# Altium schematic sheet INTERFACE.SchDoc
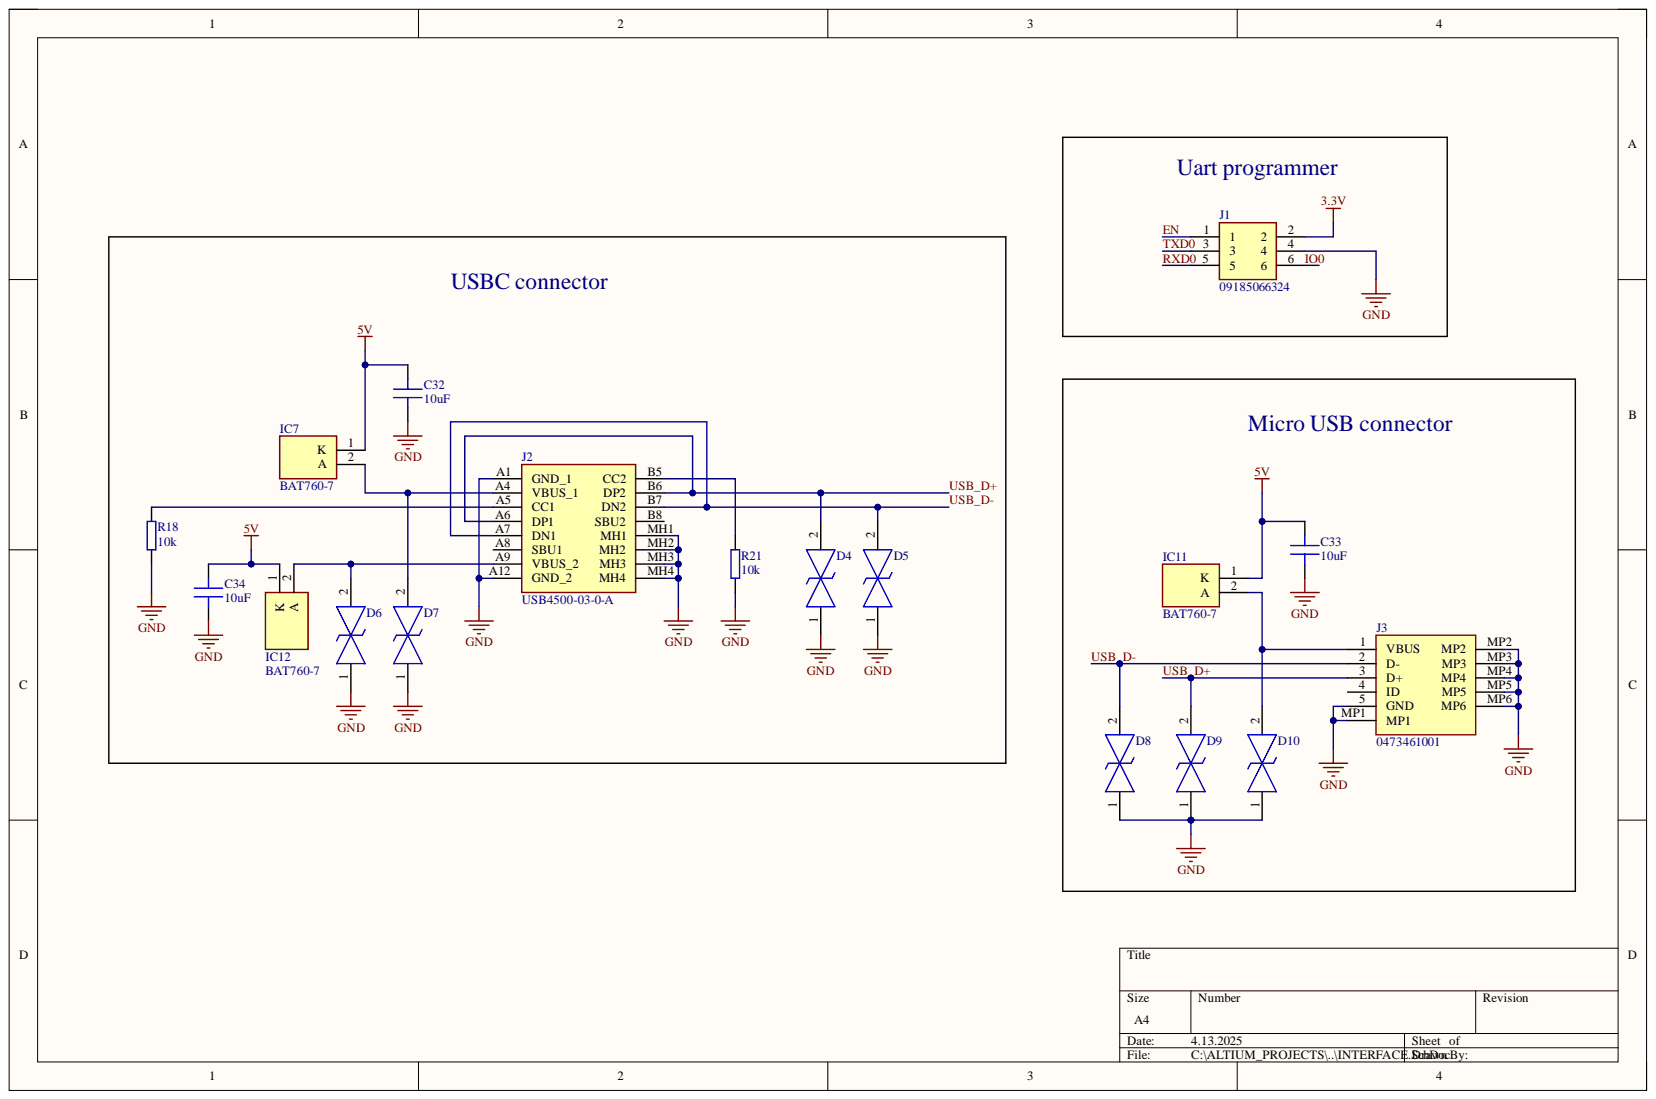
[diagram p0: INTERFACE.SchDoc]

## Parts
C32  10uF  [C 0402]
C33  10uF  [C 0402]
C34  10uF  [C 0402]
D4  LESD5D5.0CT1G  [LESD5D50CT1G]  (value not drawn)
D5  LESD5D5.0CT1G  [LESD5D50CT1G]  (value not drawn)
D6  LESD5D5.0CT1G  [LESD5D50CT1G]  (value not drawn)
D7  LESD5D5.0CT1G  [LESD5D50CT1G]  (value not drawn)
D8  LESD5D5.0CT1G  [LESD5D50CT1G]  (value not drawn)
D9  LESD5D5.0CT1G  [LESD5D50CT1G]  (value not drawn)
D10  LESD5D5.0CT1G  [LESD5D50CT1G]  (value not drawn)
IC7  BAT760-7  [SOD2513X120N]
IC11  BAT760-7  [SOD2513X120N]
IC12  BAT760-7  [SOD2513X120N]
J1  09185066324  [09185066324]
J2  USB4500-03-0-A  [USB4500030A]
J3  0473461001  [0473461001]
R18  10k  [R 0402]
R21  10k  [R 0402]

## Nets
3.3V: J1.2
5V: C32.1 C33.1 C34.1 IC7.1 IC11.1 IC12.1
GND: C32.2 C33.2 C34.2 D4.1 D5.1 D6.1 D7.1 D8.1 D9.1 D10.1 J1.4 J2.A1(GND_1) J2.A12(GND_2) J2.MH1 J2.MH2 J2.MH3 J2.MH4 J3.5(GND) J3.MP1 J3.MP2 J3.MP3 J3.MP4 J3.MP5 J3.MP6 R18.1 R21.1
EN: J1.1
IO0: J1.6
RXD0: J1.5
TXD0: J1.3
USB_D+: D4.2 D9.2 J2.A6(DP1) J2.B6(DP2) J3.3(D+)
USB_D-: D5.2 D8.2 J2.A7(DN1) J2.B7(DN2) J3.2(D-)
NetD6_2: D6.2 IC12.2 J2.A9(VBUS_2)
NetD7_2: D7.2 IC7.2 J2.A4(VBUS_1)
NetD10_2: D10.2 IC11.2 J3.1(VBUS)
NetJ2_A5: J2.A5(CC1) R18.2
NetJ2_B5: J2.B5(CC2) R21.2

Not connected: J2 A8(SBU1) B8(SBU2); J3 4(ID)

## Library data of the parts
C32-C34 = Capacitor: MLCC Capacitor; Component Kind: Standard; Library Name: Components.IntLib
D4-D10 = LESD5D5.0CT1G: TVS Diode (Bi-directional); Manufacturer_Name: LRC; Component Kind: Standard; Height: 0.7mm; Manufacturer_Part_Number: LESD5D5.0CT1G; Library Name: caravan_sch_lib.SchLib
IC7 IC11 IC12 = BAT760-7: Integrated Circuit; Manufacturer_Name: Lattice Semiconductor; Component Kind: Standard; Datasheet Link: https://www.diodes.com/assets/Datasheets/ds30498.pdf; Height: 1.2mm; Manufacturer_Part_Number: BAT760-7; Mouser Part Number: N/A; Mouser Price/Stock: https://www.mouser.com/Search/Refine.aspx?Keyword=N%2FA; Library Name: caravan_sch_lib.SchLib
J1 = 09185066324: Connector; Manufacturer_Name: HARTING; Arrow Part Number: 09185066324; Arrow Price/Stock: https://www.arrow.com/en/products/09185066324/harting?utm_currency=USD&region=europe; Component Kind: Standard; Datasheet Link: https://b2b.harting.com/files/download/PRD/PDF_DS/PDF_DS_09185066324_EN.pdf; Manufacturer_Part_Number: 09185066324; Mouser Part Number: 617-09185066324; Mouser Price/Stock: https://www.mouser.co.uk/ProductDetail/HARTING/09185066324?qs=qa49y27LPCE%2F3ZplMsKEeQ%3D%3D; Library Name: caravan_sch_lib.SchLib
J2 = USB4500-03-0-A: Connector; Manufacturer_Name: GCT (GLOBAL CONNECTOR TECHNOLOGY); Component Kind: Standard; Datasheet Link: https://componentsearchengine.com/Datasheets/2/USB4500-03-0-A.pdf; Manufacturer_Part_Number: USB4500-03-0-A; Mouser Part Number: 640-USB4500030A; Mouser Price/Stock: https://www.mouser.co.uk/ProductDetail/GCT/USB4500-03-0-A?qs=7D1LtPJG0i2mVyw7UtV%2FkQ%3D%3D; Library Name: caravan_sch_lib.SchLib
J3 = 0473461001: Connector; Manufacturer_Name: Molex; Arrow Part Number: 0473461001; Arrow Price/Stock: https://www.arrow.com/en/products/0473461001/molex?region=nac; Component Kind: Standard; Datasheet Link: https://componentsearchengine.com/Datasheets/1/0473461001.pdf; Height: 2.45mm; Manufacturer_Part_Number: 0473461001; Mouser Part Number: N/A; Mouser Price/Stock: https://www.mouser.co.uk/ProductDetail/Molex/0473461001?qs=2bnkQPT%252BPafTZgGVt%2FOGfA%3D%3D
R18 R21 = Resistor: General pourpouse resistor; Component Kind: Standard; Library Name: Components.IntLib
